# Altium schematic sheet LEDs.SchDoc
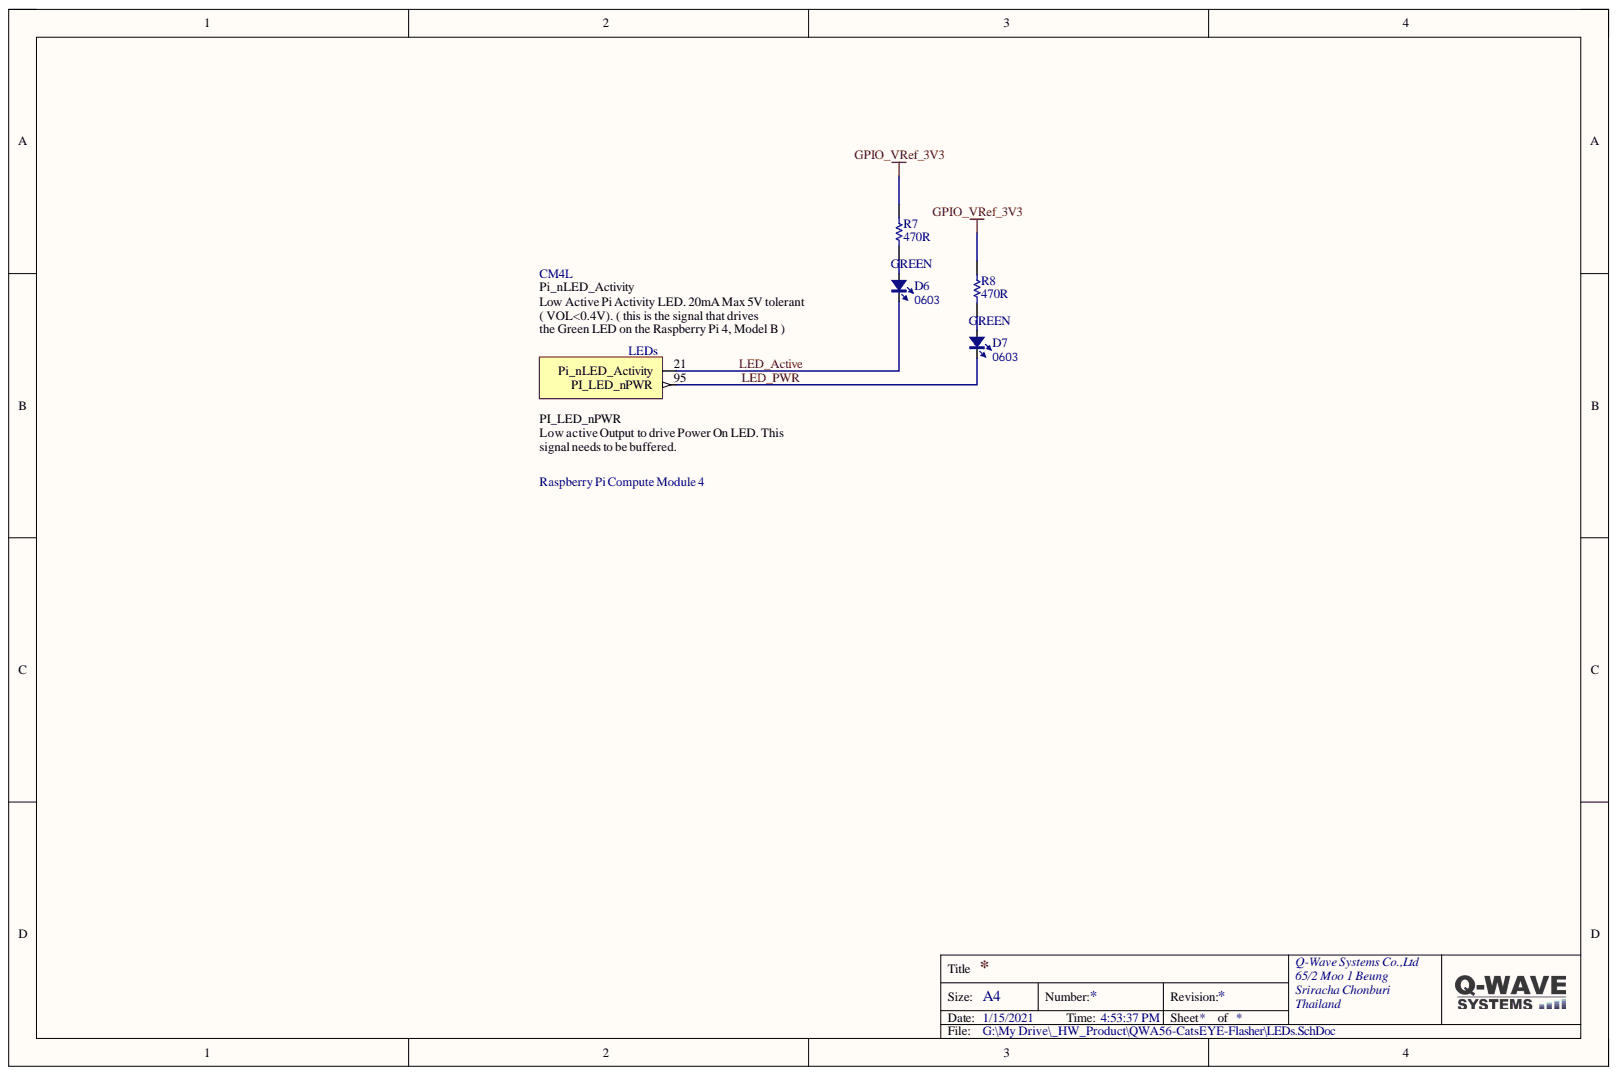
[diagram p0: LEDs.SchDoc]

## Parts
CM4  Raspberry Pi Compute Module 4  [CM4-F40C-100DS-0.4v_1.5mm_0mm]  (part L)
D6  GREEN  Type: 0603  [LED_0603_BLUE]
D7  GREEN  Type: 0603  [LED_0603_BLUE]
R7  470R  [RES_0603]
R8  470R  [RES_0603]

## Nets
GPIO_VRef_3V3: R7.2 R8.2
LED_Active: CM4.21 D6.2
LED_PWR: CM4.95 D7.2
NetD6_1: D6.1 R7.1
NetD7_1: D7.1 R8.1

## Library data of the parts
CM4 = CM4: Component Kind: Standard; Description: Raspberry Pi Compute Module 4; Library Name: CM4.SchLib
D6 D7 = LED_0603: LED; Value: color?; Component Kind: Standard; Library Name: SCH_Active.SchLib
R7 R8 = Res Semi: 470R Resistor 0603; Component Kind: Standard; Library Name: SCH_Passive.SchLib; Signal Integrity: Res Semi; Simulation: RES
